# Altium schematic sheet Sheet1.SchDoc
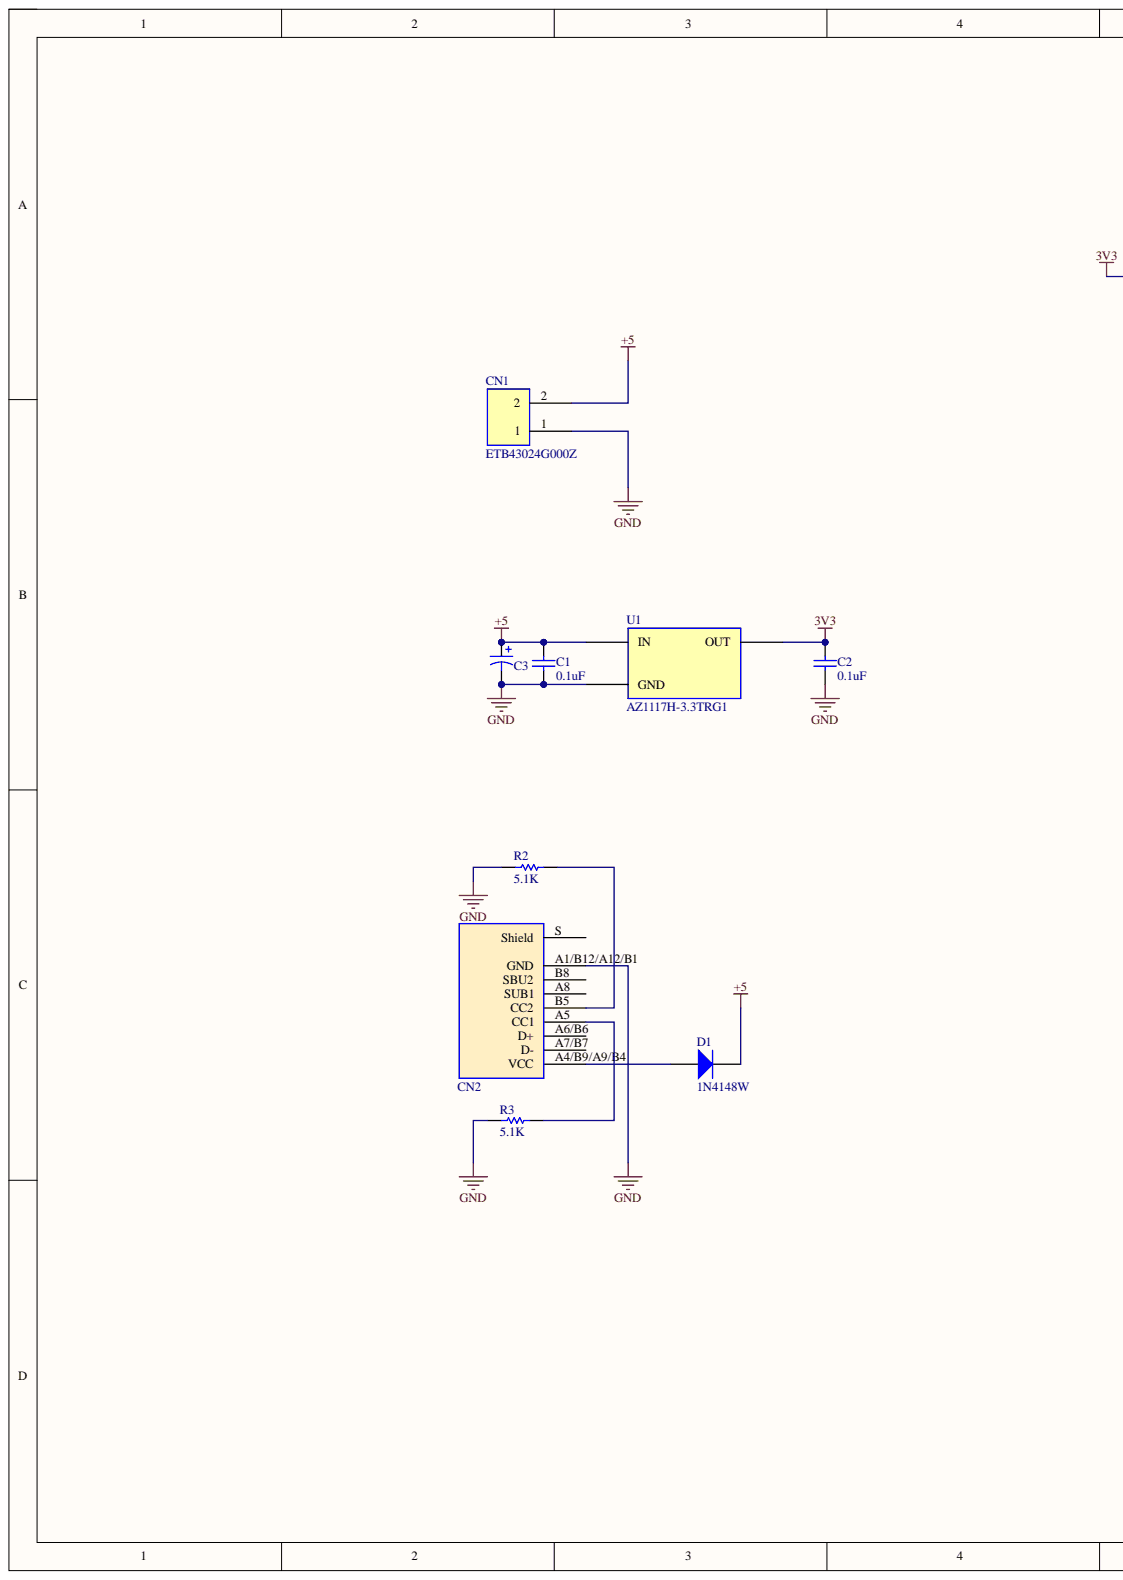
[diagram p0: Sheet1.SchDoc, left]
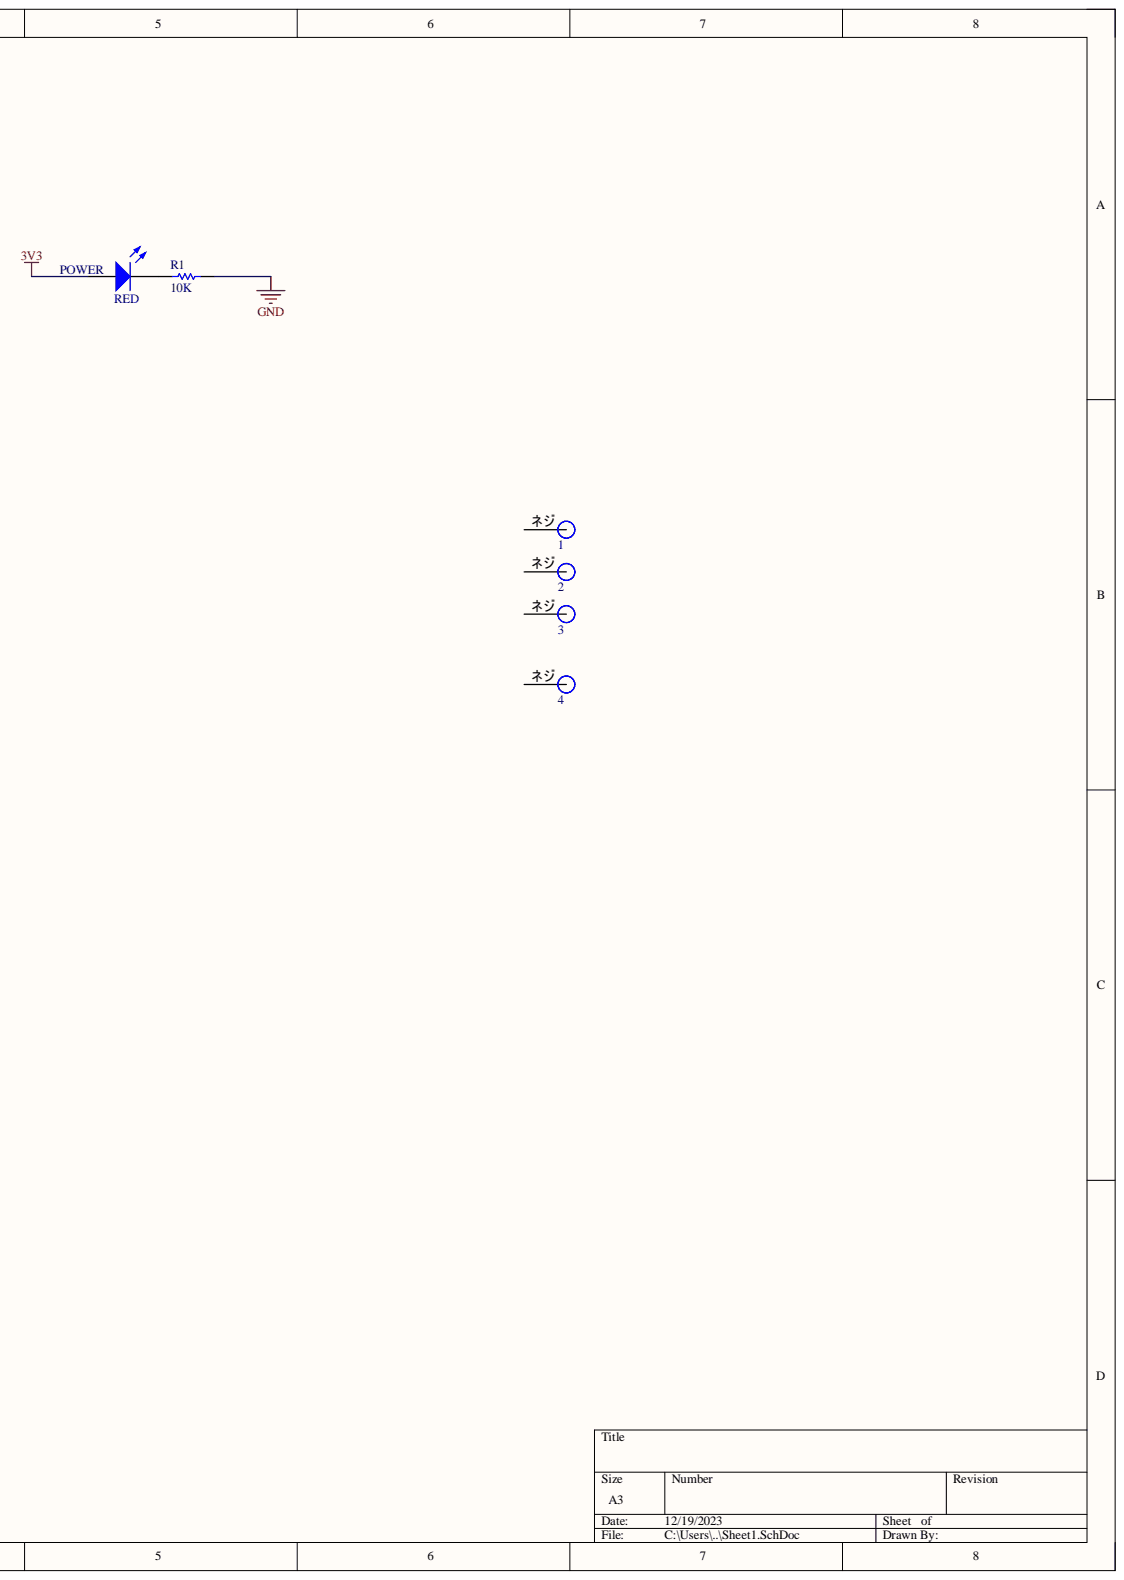
[diagram p1: Sheet1.SchDoc, right]

## Parts
1  ネジ  [M3ネジ]
2  ネジ  [M3ネジ]
3  ネジ  [M3ネジ]
4  ネジ  [M3ネジ]
C1  value 0.1uF  [Capacitor_1608]  (hidden comment: Condenser_1608)
C2  value 0.1uF  [Capacitor_1608]  (hidden comment: Condenser_1608)
C3  47uF  [UZS1A470MCL1GB]  (value not drawn)
CN1  ETB43024G000Z  [ETB43024G000Z]
CN2  USB2.0-Type-C  [TYPE-C-31-M-12]  (value not drawn)
D1  1N4148W  [1N4148W]
POWER  value RED  [LED_1608]  (hidden comment: LED_1608)
R1  value 10K  [Resistance_1608]  (hidden comment: Resista_1608)
R2  value 5.1K  [Resistance_1608]  (hidden comment: Resista_1608)
R3  value 5.1K  [Resistance_1608]  (hidden comment: Resista_1608)
U1  AZ1117H-3.3TRG1  [AZ1117H-3.3TRG1]

## Nets
3V3: C2.2 POWER.A U1.2
+5: C1.2 C3.+ CN1.2 D1.K U1.3
GND: C1.1 C2.1 C3.- CN1.1 CN2.A1/B12/A12/B1(GND) R1.1 R2.1 R3.1 U1.1
NetCN2_A4/B9/A9/B4: CN2.A4/B9/A9/B4(VCC) D1.A
NetCN2_A5: CN2.A5(CC1) R3.2
NetCN2_B5: CN2.B5(CC2) R2.2
NetPOWER_C: POWER.C R1.2

Not connected: 1 ネジ; 2 ネジ; 3 ネジ; 4 ネジ; CN2 A6/B6(D+) A7/B7(D-) A8(SUB1) B8(SBU2) S(Shield)

## Library data of the parts
1-4 = M3ネジ: Component Kind: Standard; Library Name: MyLaibrary.SchLib
C3 = UZS1A470MCL1GB: Component Kind: Standard; Value: 47uF; Library Name: MyLaibrary.SchLib
D1 = DIODE: Component Kind: Standard; Library Name: MyLaibrary.SchLib
C1 C2 = Condenser_1608: Component Kind: Standard; Library Name: MyLaibrary.SchLib
CN1 = ETB43024G000Z: Component Kind: Standard; Library Name: MyLaibrary.SchLib
CN2 = USB2.0-Type-C: Component Kind: Standard; Library Name: MyLaibrary.SchLib
POWER = LED_1608: Component Kind: Standard; Library Name: MyLaibrary.SchLib
R1-R3 = Resista_1608: Component Kind: Standard; Library Name: MyLaibrary.SchLib
U1 = AZ1117H-3.3TRG1: Component Kind: Standard; Value: AZ1117H-3.3TRG1; Library Name: MyLaibrary.SchLib
